# Altium schematic sheet ZYNQ_JTAG.SchDoc(ZYNQ_JTAG)
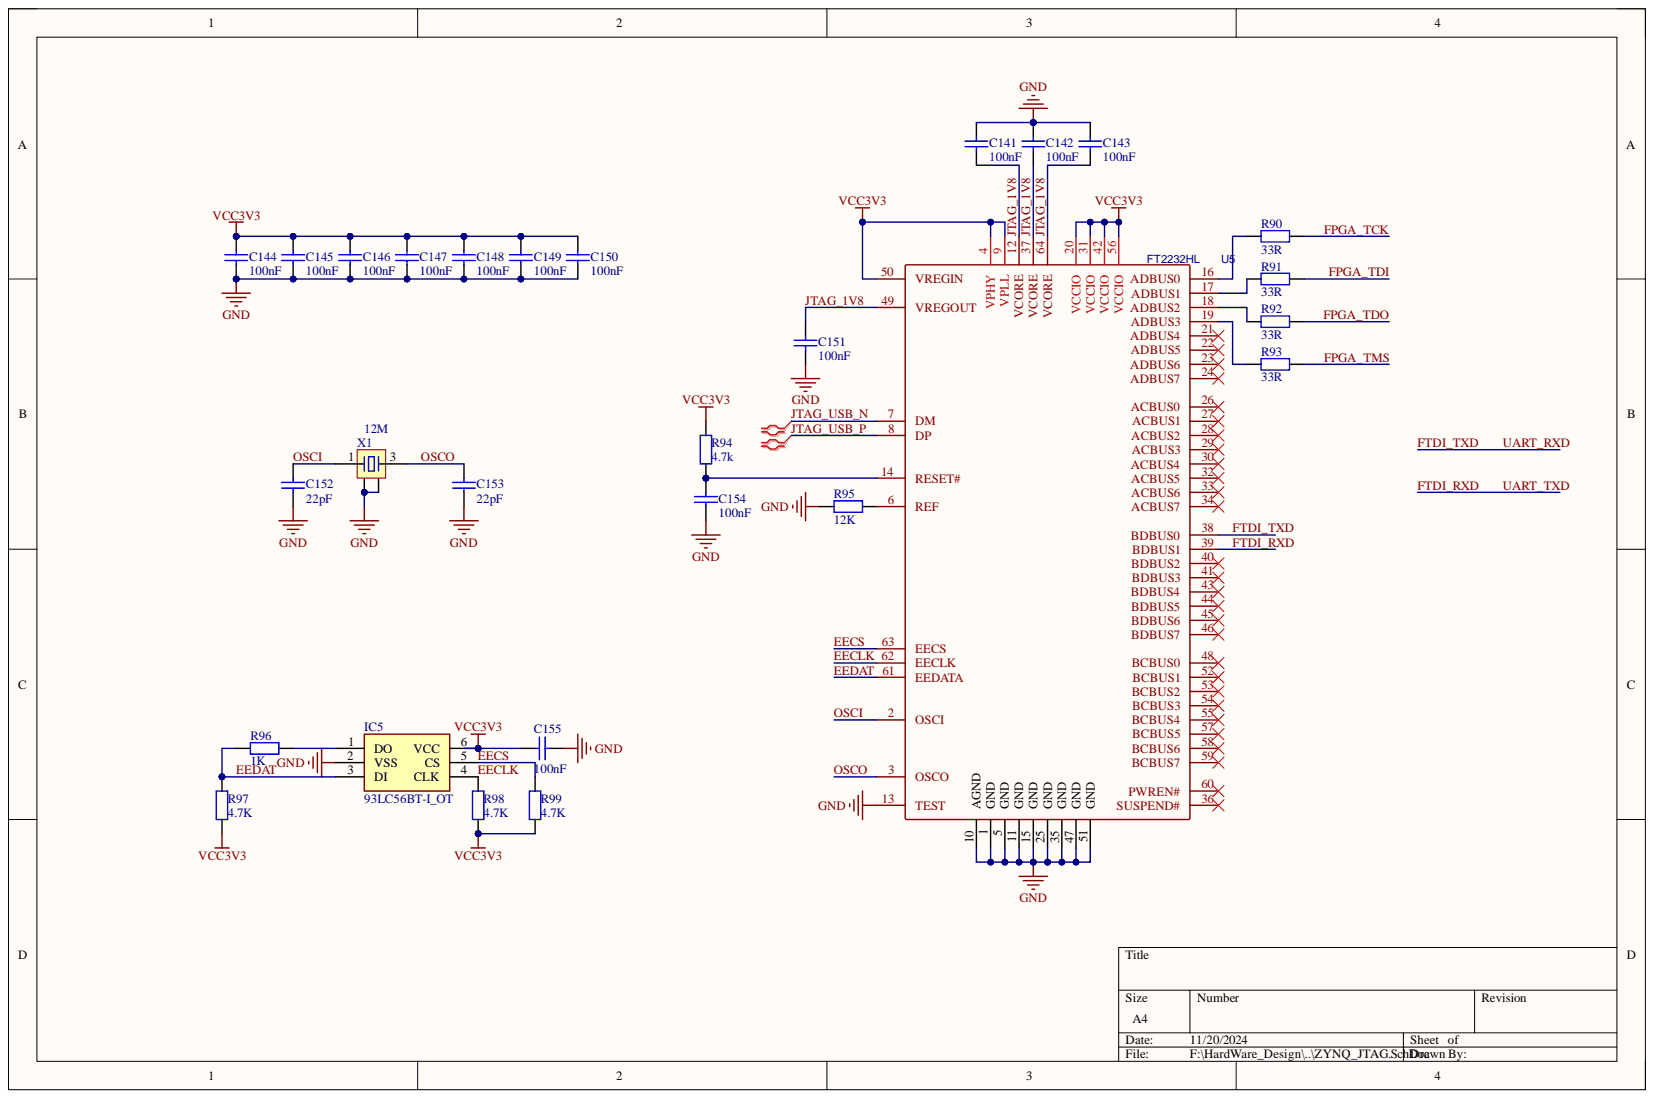
[diagram p0: ZYNQ_JTAG.SchDoc]

## Parts
C141  100nF  [C 0402_L]
C142  100nF  [C 0402_L]
C143  100nF  [C 0402_L]
C144  100nF  [C 0402_L]
C145  100nF  [C 0402_L]
C146  100nF  [C 0402_L]
C147  100nF  [C 0402_L]
C148  100nF  [C 0402_L]
C149  100nF  [C 0402_L]
C150  100nF  [C 0402_L]
C151  100nF  [C 0402_L]
C152  22pF  [C 0402_L]
C153  22pF  [C 0402_L]
C154  100nF  [C 0402_L]
C155  100nF  [C 0402_L]
IC5  93LC56BT-I_OT  [SOT95P270X145-6N]
R90  33R  [R 0402_L]
R91  33R  [R 0402_L]
R92  33R  [R 0402_L]
R93  33R  [R 0402_L]
R94  4.7k  [R 0402_L]
R95  12K  [R 0402_L]
R96  1K  [R 0402_L]
R97  4.7K  [R 0402_L]
R98  4.7K  [R 0402_L]
R99  4.7K  [R 0402_L]
U5  FT2232HL  [LQFP64 10x10_L]
X1  12M  [OSC 3225-4P]

## Nets
GND: C141.2 C142.2 C143.2 C144.1 C145.1 C146.1 C147.1 C148.1 C149.1 C150.1 C151.1 C152.1 C153.1 C154.1 C155.1 IC5.2(VSS) R95.2 U5.1(GND) U5.5(GND) U5.10(AGND) U5.11(GND) U5.13(TEST) U5.15(GND) U5.25(GND) U5.35(GND) U5.47(GND) U5.51(GND) X1.2 X1.4
VCC3V3: C144.2 C145.2 C146.2 C147.2 C148.2 C149.2 C150.2 C155.2 IC5.6(VCC) R94.2 R97.2 R98.2 R99.2 U5.4(VPHY) U5.9(VPLL) U5.20(VCCIO) U5.31(VCCIO) U5.42(VCCIO) U5.50(VREGIN) U5.56(VCCIO)
EECLK: IC5.4(CLK) R98.1 U5.62(EECLK)
EECS: IC5.5(CS) R99.1 U5.63(EECS)
EEDAT: IC5.3(DI) R96.2 R97.1 U5.61(EEDATA)
FPGA_TCK: R90.1
FPGA_TDI: R91.1
FPGA_TDO: R92.1
FPGA_TMS: R93.1
FTDI_RXD: U5.39(BDBUS1)
FTDI_TXD: U5.38(BDBUS0)
JTAG_1V8: C141.1 C142.1 C143.1 C151.2 U5.12(VCORE) U5.37(VCORE) U5.49(VREGOUT) U5.64(VCORE)
JTAG_USB_N: U5.7(DM)
JTAG_USB_P: U5.8(DP)
OSCI: C152.2 U5.2(OSCI) X1.1
OSCO: C153.2 U5.3(OSCO) X1.3
NetC154_2: C154.2 R94.1 U5.14(RESET#)
NetIC5_1: IC5.1(DO) R96.1
NetR90_2: R90.2 U5.16(ADBUS0)
NetR91_2: R91.2 U5.17(ADBUS1)
NetR92_2: R92.2 U5.18(ADBUS2)
NetR93_2: R93.2 U5.19(ADBUS3)
NetR95_1: R95.1 U5.6(REF)

Not connected: U5 21(ADBUS4) 22(ADBUS5) 23(ADBUS6) 24(ADBUS7) 26(ACBUS0) 27(ACBUS1) 28(ACBUS2) 29(ACBUS3) 30(ACBUS4) 32(ACBUS5) 33(ACBUS6) 34(ACBUS7) 36(SUSPEND#) 40(BDBUS2) 41(BDBUS3) 43(BDBUS4) 44(BDBUS5) 45(BDBUS6) 46(BDBUS7) 48(BCBUS0) 52(BCBUS1) 53(BCBUS2) 54(BCBUS3) 55(BCBUS4) 57(BCBUS5) 58(BCBUS6) 59(BCBUS7) 60(PWREN#)

## Library data of the parts
C141-C155 = Cap: 贴片电容; Component Kind: Standard; Library Name: A_Schlib.SchLib
  C141-C151 C154 C155: value: 100nF
  C152 C153: value: 22pF
IC5 = 93LC56BT-I_OT: Integrated Circuit; Manufacturer_Name: Microchip; Manufacturer_Part_Number: 93LC56BT-I/OT; Arrow Part Number: 93LC56BT-I/OT; Arrow Price/Stock: https://www.arrow.com/en/products/93lc56bt-iot/microchip-technology?region=nac; Component Kind: Standard; Datasheet Link: http://ww1.microchip.com/downloads/en/DeviceDoc/21794G.pdf; Height: 1.45mm; Library Name: SamacSys.SchLib; Mouser Part Number: 579-93LC56BT-I/OT; Mouser Price/Stock: https://www.mouser.co.uk/ProductDetail/Microchip-Technology/93LC56BT-I-OT?qs=lQv0JY93vrc7B5Rh5LPW8g%3D%3D
R90-R99 = Res: 通用; Component Kind: Standard; Library Name: A_Schlib.SchLib
  R90-R93: value: 33R
  R94: value: 4.7k
  R95: value: 12K
  R96: value: 1K
  R97-R99: value: 4.7K
U5 = FT2232HL: Manufacturer: FTDI(飞特帝亚); Manufacturer Part: FT2232HL-REEL; sourceId: X3DdNmkiu; Component Kind: Standard; JLCPCB Part Class: Extended Part; Library Name: A_Schlib.SchLib; spicePre: U; spiceSymbolName: FT2232HL; Supplier: LCSC; Supplier Part: C27882; timeStamp: 1472800160
X1 = XTAL-4P: 4脚无源晶振; 版权归属: 封装库来自淘宝卖家 ID：黄晶宝石; BOM名称: 4脚无源晶振; Component Kind: Standard; Library Name: A_Schlib.SchLib; 学习资源: https://shop463601444.taobao.com
